# Altium schematic sheet GWMS.SchDoc (continued)

## Library data of the parts
C1 C3 C5 C7 C9 C12 C14 C16 C18 = Capacitor: Capacitor Non Polar; Manufacturer: Taiyo Yuden; Manufacturer Part Number: TMK316AB7106KLHT; Dielectric: X7R; Tolerance: 10%; Voltage Rating: 25V; Capacitance: 10uF; Case/Package: 1206; Case Code (Imperial): 1206; Case Code (Metric): 3216; Component Kind: Standard; Halogen Free: Halogen Free; Height: 1.6mm; Lead Free: Lead Free; Length: 0.126inch; Max Operating Temperature: 125°C; Min Operating Temperature: -55°C; Mount: Surface Mount; Package Quantity: 2000; Packaging: Tape and Reel; Pricing 1: 1 = 0.53000, 10 = 0.36800, 100 = 0.22110, 500 = 0.16580, 1000 = 0.14001 (USD); Ratings: AEC-Q200; RoHS: Yes; Simulation: CAP; Stock 1: 157997; Supplier 1: Digi-Key; Supplier Part Number 1: 587-3485-1-ND; Termination: SMD/SMT; Thickness: 0.071inch; Voltage Rating (DC): 25V; Width: 0.063inch; Library Name: RCL_roomi.IntLib
C2 C4 C6 C8 C10 C11 C13 C15 C17 C19 C20 C25 C28-C30 = Capacitor: Capacitor Non Polar; Manufacturer: Samsung; Manufacturer Part Number: CL21B104KCFWPNE; Tolerance: 10%; Voltage Rating: 100V; Capacitance: 100nF; Case/Package: 0805; Case Code (Imperial): 0805; Case Code (Metric): 2012; Component Kind: Standard; Features: Soft Termination; Length: 0.079inch; Max Operating Temperature: 125°C; Min Operating Temperature: -55°C; Mount: Surface Mount; Packaging: Tape and Reel; Pricing 1: 1 = 0.19000, 10 = 0.13400, 100 = 0.06310, 500 = 0.04422, 1000 = 0.03660 (USD); Ratings: AEC-Q200; RoHS: Yes; Simulation: CAP; Stock 1: 24573; Supplier 1: Digi-Key; Supplier Part Number 1: 1276-6733-1-ND; Thickness: 0.053inch; Width: 0.049inch; Library Name: RCL_roomi.IntLib
C21 = Capacitor: Capacitor Non Polar; Manufacturer: Samsung; Manufacturer Part Number: CL21B471KC6WPNC; Tolerance: 10%; Voltage Rating: 100V; Capacitance: 470pF; Case/Package: 0805; Case Code (Imperial): 0805; Case Code (Metric): 2012; Component Kind: Standard; Features: Soft Termination; Length: 0.079inch; Max Operating Temperature: 125°C; Min Operating Temperature: -55°C; Mount: Surface Mount; Packaging: Tape and Reel; Pricing 1: 1 = 0.12000, 10 = 0.08300, 100 = 0.03930, 500 = 0.02752, 1000 = 0.02279 (USD); Ratings: AEC-Q200; RoHS: Yes; Simulation: CAP; Stock 1: 22710; Supplier 1: Digi-Key; Supplier Part Number 1: 1276-6597-1-ND; Thickness: 0.028inch; Width: 0.049inch; Library Name: RCL_roomi.IntLib
C22 = Capacitor: Capacitor Non Polar; Manufacturer: Murata; Manufacturer Part Number: GCJ31CR71E475KA12L; Dielectric: X7R; Tolerance: 10%; Voltage Rating: 25V; Capacitance: 4.7uF; Case/Package: 1206; Case Code (Imperial): 1206; Case Code (Metric): 3216; Component Kind: Standard; ComponentLink1Description: http://www.murata.com/~/media/webrenewal/support/library/catalog/products/capacitor/mlcc/c03e.ashx; ComponentLink1URL: http://www.murata.com/~/media/webrenewal/support/library/catalog/products/capacitor/mlcc/c03e.ashx; ComponentLink2Description: http://search.murata.co.jp/Ceramy/image/img/A01X/partnumbering_e_02.pdf; ComponentLink2URL: http://search.murata.co.jp/Ceramy/image/img/A01X/partnumbering_e_02.pdf; Depth: 1.6mm; Features: Soft Termination; Lead Free: Lead Free; Length: 0.126inch; Max Operating Temperature: 125°C; Min Operating Temperature: -55°C; Mount: Surface Mount; Package Quantity: 2000; Packaging: Tape and Reel; Pricing 1: 1 = 0.82000, 10 = 0.57600, 100 = 0.37950, 500 = 0.28114, 1000 = 0.23897 (USD); Ratings: AEC-Q200; RoHS: Yes; Simulation: CAP; Stock 1: 80568; Supplier 1: Digi-Key; Supplier Part Number 1: 490-5808-1-ND; Termination: SMD/SMT; Thickness: 0.075inch; Voltage Rating (DC): 25V; Width: 0.063inch; Library Name: RCL_roomi.IntLib
C23 = Capacitor-Pol: Capacitor Polar; Manufacturer: Panasonic; Manufacturer Part Number: EEEHC1C471P; Dielectric Material: Aluminium; Leakage Current: 3uA; Life (Hours): 5000Hour; Ripple Current: 340mA; Tolerance: 20%; Capacitance: 470uF; Case/Package: Radial; Component Kind: Standard; ComponentLink1Description: http://www.farnell.com/datasheets/2059746.pdf; ComponentLink1URL: http://www.farnell.com/datasheets/2059746.pdf; ComponentLink2Description: http://industrial.panasonic.com/www-data/pdf/ABA0000/ABA0000CE109.pdf; ComponentLink2URL: http://industrial.panasonic.com/www-data/pdf/ABA0000/ABA0000CE109.pdf; ComponentLink3Description: http://www.farnell.com/datasheets/2244689.pdf; ComponentLink3URL: http://www.farnell.com/datasheets/2244689.pdf; ComponentLink4Description: http://www.farnell.com/datasheets/370234.pdf; ComponentLink4URL: http://www.farnell.com/datasheets/370234.pdf; ComponentLink5Description: https://www.alliedelec.com/m/d/b5cedb557dd62c1c43280c1d7d86d6d0.pdf; ComponentLink5URL: https://www.alliedelec.com/m/d/b5cedb557dd62c1c43280c1d7d86d6d0.pdf; ComponentLink6Description: http://www.mouser.com/ds/2/315/ABA0000C1160-947462.pdf; ComponentLink6URL: http://www.mouser.com/ds/2/315/ABA0000C1160-947462.pdf; ComponentLink7Description: http://uk.rs-online.com/webdocs/0f32/0900766b80f32c51.pdf; ComponentLink7URL: http://uk.rs-online.com/webdocs/0f32/0900766b80f32c51.pdf; Contact Plating: Tin; Diameter: 10mm; Height: 10.2mm; Height - Seated (Max): 0.402inch; Lead Free: Lead Free; Lead Pitch: 4.6mm; Length: 10.2mm; Max Operating Temperature: 105°C; Min Operating Temperature: -40°C; Mount: PCB,Surface Mount; Number of Pins: 2; Package Quantity: 500; Packaging: Tape and Reel; Polarity: Polar; Pricing 1: 1 = 0.85000, 10 = 0.62600, 100 = 0.42920 (USD); Radiation Hardening: No; REACH SVHC: No SVHC; Ripple Current (AC): 340mA; RoHS: Yes; Simulation: CAP; Stock 1: 14134; Supplier 1: Digi-Key; Supplier Part Number 1: PCE3751CT-ND; Termination: SMD/SMT; Voltage Rating: 16V; Voltage Rating (DC): 16V; Width: 900um; Library Name: RCL_roomi.IntLib
C24 C27 = Capacitor: Ceramic Chip Capacitor; Manufacturer: Samsung; Manufacturer Part Number: CL21B104KCFWPNE; Tolerance: 10%; Voltage Rating: 100V; Capacitance: 100nF; Case/Package: 0805; Case Code (Imperial): 0805; Case Code (Metric): 2012; Component Kind: Standard; Features: Soft Termination; Length: 0.079inch; Max Operating Temperature: 125°C; Min Operating Temperature: -55°C; Mount: Surface Mount; Packaging: Tape and Reel; Pricing 1: 1 = 0.19000, 10 = 0.13400, 100 = 0.06310, 500 = 0.04422, 1000 = 0.03660 (USD); Ratings: AEC-Q200; RoHS: Yes; Simulation: CAP; Stock 1: 24573; Supplier 1: Digi-Key; Supplier Part Number 1: 1276-6733-1-ND; Thickness: 0.053inch; Width: 0.049inch; Library Name: RCL_roomi.IntLib
C26 = Capacitor: Ceramic Chip Capacitor; Manufacturer: Taiyo Yuden; Manufacturer Part Number: TMK316AB7106KLHT; Dielectric: X7R; Tolerance: 10%; Voltage Rating: 25V; Capacitance: 10uF; Case/Package: 1206; Case Code (Imperial): 1206; Case Code (Metric): 3216; Component Kind: Standard; Halogen Free: Halogen Free; Height: 1.6mm; Lead Free: Lead Free; Length: 0.126inch; Max Operating Temperature: 125°C; Min Operating Temperature: -55°C; Mount: Surface Mount; Package Quantity: 2000; Packaging: Tape and Reel; Pricing 1: 1 = 0.53000, 10 = 0.36800, 100 = 0.22110, 500 = 0.16580, 1000 = 0.14001 (USD); Ratings: AEC-Q200; RoHS: Yes; Simulation: CAP; Stock 1: 157997; Supplier 1: Digi-Key; Supplier Part Number 1: 587-3485-1-ND; Termination: SMD/SMT; Thickness: 0.071inch; Voltage Rating (DC): 25V; Width: 0.063inch; Library Name: RCL_roomi.IntLib
D1 = Diode_Schottky: Manufacturer: ON Semiconductor / Fairchild; Average Rectified Current: 1A; Element Configuration: Single; Max Forward Surge Current (Ifsm): 40A; Max Repetitive Reverse Voltage (Vrrm): 40V; Reverse Recovery Time: 5.695ns; Case/Package: SOD; Component Kind: Standard; Max Reverse Voltage (DC): 40V; Mount: Surface Mount; Packaging: Tape and Reel; Pricing 1: 1 = 0.350000, 10 = 0.263000, 100 = 0.148900, 500 = 0.098620, 1000 = 0.075610 (USD); RoHS: Yes; Stock 1: 49252; Supplier 1: Digi-Key; Supplier Part Number 1: SS14FLCT-ND; Weight: 0.0276g; Library Name: Diodes_roomi.IntLib
D2 = Diode_Schottky: Manufacturer: Diodes; Average Rectified Current: 3A; Capacitance: 250pF; Element Configuration: Single; Forward Voltage: 500mV; Max Forward Surge Current (Ifsm): 80A; Max Repetitive Reverse Voltage (Vrrm): 40V; Peak Reverse Current: 500uA; Polarity: Standard; Case/Package: SMA; Component Kind: Standard; ComponentLink1Description: http://components.arrow.com/part/dataview/41507737S9851045N2867; ComponentLink1URL: http://components.arrow.com/part/dataview/41507737S9851045N2867; ComponentLink2Description: http://www.farnell.com/datasheets/1911813.pdf; ComponentLink2URL: http://www.farnell.com/datasheets/1911813.pdf; ComponentLink3Description: http://www.farnell.com/datasheets/2238474.pdf; ComponentLink3URL: http://www.farnell.com/datasheets/2238474.pdf; ComponentLink4Description: https://www.diodes.com/assets/Datasheets/ds30891.pdf; ComponentLink4URL: https://www.diodes.com/assets/Datasheets/ds30891.pdf; ComponentLink5Description: https://www.verical.com/server-webapp/html/getDatasheet?partID=143394; ComponentLink5URL: https://www.verical.com/server-webapp/html/getDatasheet?partID=143394; Contact Plating: Matte,Tin; Current: 3A; Current Rating: 3A; Forward Current: 3A; Height: 2.3mm; Lead Free: Lead Free; Length: 4.6mm; Max Operating Temperature: 125°C; Max Reverse Voltage (DC): 40V; Min Operating Temperature: -55°C; Mount: Surface Mount; Number of Pins: 2; Output Current: 3A; Package Quantity: 5000; Packaging: Tape and Reel; Peak Non-Repetitive Surge Current: 80A; Pricing 1: 1 = 0.46000, 10 = 0.37300, 100 = 0.25380, 500 = 0.19034, 1000 = 0.14276 (USD); Radiation Hardening: No; REACH SVHC: No SVHC; RoHS: Yes; Stock 1: 741506; Supplier 1: Digi-Key; Supplier Part Number 1: B340A-FDICT-ND; Termination: SMD/SMT; Voltage: 40V; Voltage Rating (DC): 40V; Weight: 0.002258oz; Width: 2.92mm; Library Name: Diodes_roomi.IntLib
FID1-FID3 = Fiducials: Alignment mark for the pick & place machine; Library Name: Misc_roomi.IntLib
J1 J2 J9 J10 = TERM_BLK-2P: Terminal Block 2 position; Manufacturer: Phoenix Contact; Manufacturer Part Number: 1729128; Approvals: CSA,UL; Connector Type: Screw; Current: 10A; Current Rating: 13.5A; Max Supply Voltage: 400V; Number of Circuits: 2; Number of Levels: 1; Pitch: 5.08mm; Test Voltage: 4kV; Voltage: 300V; Wire/Cable Gauge: 26AWG; Wire Gauge (Min): 14AWG; Color: Green; Component Kind: Standard; ComponentLink1Description: https://media.digikey.com/pdf/Data%20Sheets/Phoenix%20Contact%20PDFs/1729128.pdf; ComponentLink1URL: https://media.digikey.com/pdf/Data%20Sheets/Phoenix%20Contact%20PDFs/1729128.pdf; ComponentLink2Description: http://www.alliedelec.com/m/d/8fbcabaafcd0c56253c6cd72df81298d.pdf; ComponentLink2URL: http://www.alliedelec.com/m/d/8fbcabaafcd0c56253c6cd72df81298d.pdf; ComponentLink3Description: https://www.verical.com/server-webapp/html/getDatasheet?partID=199310; ComponentLink3URL: https://www.verical.com/server-webapp/html/getDatasheet?partID=199310; ComponentLink4Description: https://produktinfo.conrad.com/datenblaetter/725000-749999/743664-da-01-en-PRINTKLEMMENBLOCK_MKDSN_1_5__2_5_08.pdf; ComponentLink4URL: https://produktinfo.conrad.com/datenblaetter/725000-749999/743664-da-01-en-PRINTKLEMMENBLOCK_MKDSN_1_5__2_5_08.pdf; Contact Material: Tin; Depth: 8.1mm; Flammability Rating: UL94 V-0; Height: 13.5mm; Housing Color: Green; Housing Material: Polyamide; Insulation Material: Nylon; Lead Free: Lead Free; Length: 8.1mm; Max Current Rating: 13.5A; Max Operating Temperature: 100°C; Min Operating Temperature: -20°C; Mount: Through Hole; Number of Contacts: 2; Number of Pins: 2; Number of Poles: 2; Number of Positions: 2; Number of Positions Per Level: 2; Number of Rows: 1; Orientation: Straight; Package Quantity: 50; Packaging: Bulk; Pricing 1: 1 = 1.10000, 10 = 1.05100, 50 = 1.00480, 100 = 0.97740, 250 = 0.91352, 500 = 0.84042, 1000 = 0.77647, 2500 = 0.73994, 5000 = 0.69426 (USD); Radiation Hardening: No; REACH SVHC: No SVHC; RoHS: Yes; Stock 1: 62; Supplier 1: Digi-Key; Supplier Part Number 1: 277-1247-ND; Termination: Screw; Voltage Rating: 400V; Weight: 0.069842oz; Width: 13.5mm; Wire Gauge (Max): 26AWG; Library Name: Con_roomi.IntLib
J3 = HDR 1X3: HEADER 1X3; Component Kind: Standard; Library Name: Con_roomi.IntLib
J4 J18-J20 = Jumper-3P_NC: Component Kind: Net Tie; Library Name: Misc_roomi.IntLib
J5-J8 = HDR 1X3: HEADER 1X3; Manufacturer: Sullins; Manufacturer Part Number: PPPC031LFBN-RC; Connector Type: Header,Socket; Number of Positions: 3; Pitch: 0.1inch; Color: Black; Component Kind: Standard; Contact Material: Bronze; Contact Plating: Gold; Height: 8.5mm; Housing Material: Plastic; Lead Free: Lead Free; Length: 8.12mm; Mount: Through Hole; Number of Rows: 1; Package Quantity: 1000; Packaging: Bulk; Pricing 1: 1 = 0.37000, 10 = 0.35100, 100 = 0.26910, 500 = 0.23400, 1000 = 0.19305, 5000 = 0.16965, 10000 = 0.16900 (USD); RoHS: Yes; Stock 1: 16844; Supplier 1: Digi-Key; Supplier Part Number 1: S7036-ND; Termination: Solder; Width: 2.5mm; Library Name: Con_roomi.IntLib
J11 = HDR 1X2: HEADER 1X2; Component Kind: Standard; Library Name: Con_roomi.IntLib
J12 = TERM_BLK-5P: Terminal Block 4 position; Manufacturer: Phoenix Contact; Manufacturer Part Number: 1729157; Approvals: CB,CSA,cULus,UL; Connector Type: Screw; Current: 10A; Current Rating: 13.5A; Max Supply Voltage: 250V; Number of Circuits: 5; Number of Levels: 1; Pitch: 5.08mm; Stripping Length: 6mm; Voltage: 300V; Wire/Cable Gauge: 26AWG; Wire Gauge (Min): 14AWG; Color: Green; Component Kind: Standard; ComponentLink1Description: https://media.digikey.com/pdf/Data%20Sheets/Phoenix%20Contact%20PDFs/1729157.pdf?src-supplier=Digi-Key; ComponentLink1URL: https://media.digikey.com/pdf/Data%20Sheets/Phoenix%20Contact%20PDFs/1729157.pdf?src-supplier=Digi-Key; ComponentLink2Description: https://www.verical.com/server-webapp/html/getDatasheet?partID=238078&src-supplier=Verical; ComponentLink2URL: https://www.verical.com/server-webapp/html/getDatasheet?partID=238078&src-supplier=Verical; ComponentLink3Description: https://www.alliedelec.com/m/d/55f89f4a8d0b80308322c2ef4a018ccf.pdf?src-supplier=Allied+Electronics; ComponentLink3URL: https://www.alliedelec.com/m/d/55f89f4a8d0b80308322c2ef4a018ccf.pdf?src-supplier=Allied+Electronics; ComponentLink4Description: http://www.farnell.com/datasheets/2309723.pdf?src-supplier=Farnell; ComponentLink4URL: http://www.farnell.com/datasheets/2309723.pdf?src-supplier=Farnell; Contact Material: Tin; Depth: 8.1mm; Flammability Rating: UL94 V-0; Height: 13.5mm; Housing Color: Green; Housing Material: Polyamide; Insulation Material: Nylon; Lead Free: Lead Free; Length: 8.1mm; Material: Nylon; Max Current Rating: 13.5A; Mount: Through Hole; Number of Contacts: 5; Number of Pins: 5; Number of Poles: 5; Number of Positions: 5; Number of Positions Per Level: 5; Number of Rows: 1; Orientation: Straight; Package Quantity: 50; Packaging: Bulk; Pricing 1: 1 = 2.75000, 10 = 2.63200, 50 = 2.51800, 100 = 2.44920, 250 = 2.28900, 500 = 2.10590, 1000 = 1.94566, 2500 = 1.85409, 5000 = 1.73965 (USD); Radiation Hardening: No; REACH SVHC: No SVHC; RoHS: Yes; Stock 1: 3989; Supplier 1: Digi-Key; Supplier Part Number 1: 277-1250-ND; Termination: Solder; Voltage Rating: 250V; Weight: 0.160849oz; Width: 25.4mm; Wire Gauge (Max): 26AWG; Library Name: Con_roomi.IntLib
J13 J15 = HDR 1X4: HEADER 1X4; Component Kind: Standard; Library Name: Con_roomi.IntLib
J14 = JST-2P: JST 2 Pin, Shrouded Connector; Connector Type: Male Pin; Contact Resistance: 20mR; Current Rating: 2A; Gender: Male; Insulation Resistance: 1MR; Max Voltage Rating (AC): 100V; Number of Contacts: 2; Pitch: 2mm; Color: Natural; Component Kind: Standard; ComponentLink1Description: http://www.farnell.com/datasheets/2057211.pdf; ComponentLink1URL: http://www.farnell.com/datasheets/2057211.pdf; ComponentLink2Description: http://www.jst-mfg.com/product/pdf/eng/ePH.pdf; ComponentLink2URL: http://www.jst-mfg.com/product/pdf/eng/ePH.pdf; ComponentLink3Description: http://www1.futureelectronics.com/doc/JST/B2B-PH-K-S(LF)(SN).pdf; ComponentLink3URL: http://www1.futureelectronics.com/doc/JST/B2B-PH-K-S(LF)(SN).pdf; Contact Material: Brass,Tin; Contact Mating Length: 0.13inch; Contact Plating: Copper,Tin; Depth: 4.5mm; Fastening Type: Detent; Height: 9.4mm; Housing Color: White; Housing Material: Polyamide; Lead Free: Lead Free; Lead Pitch: 2mm; Length: 5.9mm; Manufacturer: JST; Max Current Rating: 2A; Max Operating Temperature: 85°C; Max Voltage Rating (DC): 100V; Min Operating Temperature: -25°C; Mount: Through Hole; Number of Pins: 2; Number of Poles: 2; Number of Positions: 2; Number of Rows: 1; Orientation: Straight; Packaging: Bulk; Pricing 1: 1 = 0.170000, 10 = 0.162000, 100 = 0.100200, 500 = 0.082880, 1000 = 0.072520, 5000 = 0.062160, 10000 = 0.060440 (USD); REACH SVHC: No SVHC; RoHS: Yes; Stock 1: 457571; Supplier 1: Digi-Key; Supplier Part Number 1: 455-1704-ND; Termination: Solder; Voltage Rating: 100V; Voltage Rating (AC): 100V; Width: 4.5mm; Working Voltage: 100V; Library Name: Con_roomi.IntLib
J16 = Barrel Jack: DC Barrel Jack Connector Male; Manufacturer: Wurth Electronics; Connector Type: Jack,Power; Current Rating: 2A; Insulation Resistance: 100MR; Shielding: Unshielded; Voltage Rating: 30V; Color: Black; Component Kind: Standard; ComponentLink1Description: Manufacturer Link; ComponentLink1URL: http://domino.kycon.com/internet/Products.html; Contact Gender: Female; Contact Material: Copper Alloy; Contact Plating: Tin; Flammability Rating: UL94 V-0; Gender: Male; Housing Material: Polyamide; Max Operating Temperature: 85°C; Min Operating Temperature: -40°C; Mount: Through Hole; Package Quantity: 1000; Packaging: Bulk; Pricing 1: 1 = 0.84000, 10 = 0.80100, 50 = 0.71500, 100 = 0.65800, 500 = 0.57200, 1000 = 0.48700 (USD); REACH SVHC: Unknown; RoHS: Yes; Stock 1: 1123; Supplier 1: Digi-Key; Supplier Part Number 1: 732-5925-ND; Termination: Through Hole; Voltage Rating (DC): 30V; Library Name: Con_roomi.IntLib
J17 = MicroSD: MicroSD Connector; Manufacturer: Hirose; Manufacturer Part Number: DM3CS-SF; Connector Type: Connector,Socket; Contact Resistance: 100mR; Current Rating: 500mA; Gender: Female; Insulation Resistance: 1GR; Number of Contacts: 8; Pitch: 1.1mm; Voltage Rating (AC): 125V; Component Kind: Standard; ComponentLink1Description: https://www.hirose.com/product/en/download_file/key_name/DM3CS-SF/category/Specification%20Sheet/doc_file_id/44102/?file_category_id=9&item_id=06090032300&is_series=; ComponentLink1URL: https://www.hirose.com/product/en/download_file/key_name/DM3CS-SF/category/Specification%20Sheet/doc_file_id/44102/?file_category_id=9&item_id=06090032300&is_series=; ComponentLink2Description: http://www.farnell.com/datasheets/1697167.pdf; ComponentLink2URL: http://www.farnell.com/datasheets/1697167.pdf; ComponentLink3Description: http://www.hirose.co.jp/cataloge_hp/e60900232.pdf; ComponentLink3URL: http://www.hirose.co.jp/cataloge_hp/e60900232.pdf; ComponentLink4Description: http://www.mouser.com/ds/2/185/DM3_catalog-939223.pdf; ComponentLink4URL: http://www.mouser.com/ds/2/185/DM3_catalog-939223.pdf; Contact Material: Copper,Copper Alloy,Gold; Contact Plating: Gold; Depth: 1.83mm; Flammability Rating: UL94 V-0; Housing Material: Polymer; Lead Free: Lead Free; Length: 14.1mm; Max Current Rating: 500mA; Max Operating Temperature: 85°C; Min Operating Temperature: -25°C; Mount: Surface Mount; Number of Positions: 8; Number of Rows: 1; Orientation: Right Angle; Package Quantity: 1; Packaging: Tape and Reel; Pricing 1: 1 = 1.51000, 10 = 1.37400, 25 = 1.29040, 50 = 1.23420, 100 = 1.17810, 250 = 1.06592, 500 = 0.98176 (USD); Radiation Hardening: No; REACH SVHC: Unknown; RoHS: Yes; Stock 1: 67611; Supplier 1: Digi-Key; Supplier Part Number 1: HR1972CT-ND; Termination: Solder; Width: 13.8mm; Library Name: Con_roomi.IntLib
L1 = Inductor: Generic Inductor; Manufacturer: Taiyo Yuden; Manufacturer Part Number: NR5040T100M; Core Material: Ferrite; Current Rating: 2.1A; DC Resistance (DCR): 72.8mR; Inductance Tolerance: 20%; Max DC Current: 2.3A; Self Resonant Frequency: 18MHz; Shielding: Shielded; Test Frequency: 100kHz; Case/Package: Nonstandard; Component Kind: Standard; ComponentLink1Description: https://www.yuden.co.jp/productdata/catalog/en/wound04_e.pdf; ComponentLink1URL: https://www.yuden.co.jp/productdata/catalog/en/wound04_e.pdf; ComponentLink2Description: https://www.yuden.co.jp/productdata/catalog/wound04_e.pdf; ComponentLink2URL: https://www.yuden.co.jp/productdata/catalog/wound04_e.pdf; ComponentLink3Description: http://www.yuden.co.jp/productdata/catalog/en/wound04_e.pdf; ComponentLink3URL: http://www.yuden.co.jp/productdata/catalog/en/wound04_e.pdf; ComponentLink4Description: http://www.mouser.com/ds/2/396/wound04_e-8385.pdf; ComponentLink4URL: http://www.mouser.com/ds/2/396/wound04_e-8385.pdf; Composition: Wirewound; Depth: 5.1mm; Halogen Free: Halogen Free; Height: 4mm; Height - Seated (Max): 0.161inch; Inductance: 10uH; Length: 0.193inch; Max Operating Temperature: 120°C; Min Operating Temperature: -25°C; Mount: Surface Mount; Number of Pins: 2; Package Quantity: 1500; Packaging: Tape and Reel; Pricing 1: 1 = 0.45000, 10 = 0.39000, 100 = 0.27890, 500 = 0.23426 (USD); Radiation Hardening: No; REACH SVHC: Unknown; RMS Current (Irms): 2.1A; RoHS: Yes; Saturation Current: 2.3A; Stock 1: 25005; Supplier 1: Digi-Key; Supplier Part Number 1: 587-2365-1-ND; Termination: SMD/SMT; Tolerance: 20%; Width: 0.193inch; Library Name: RCL_roomi.IntLib
M1 = Particle: Particle BORON, ARGON and XENON Module; Component Kind: Standard; ComponentLink1Description: http://www.microchip.com/mymicrochip/filehandler.aspx?ddocname=en570043; ComponentLink1URL: http://www.microchip.com/mymicrochip/filehandler.aspx?ddocname=en570043; Manufacturer: Particle; Mounting Type: Through Hole; Operating Temperature: -20°C ~ 60°C; Package / Case: Module; Library Name: Modules_Roomi.IntLib
Q1 Q2 = MOSFET-N_D: N-Channel Power MOSFET with Diode; Manufacturer: ON Semiconductor; Continuous Drain Current (ID): 320mA; Drain to Source Breakdown Voltage: 60V; Drain to Source Resistance: 1.6R; Element Configuration: Single; Fall Time: 9ns; Gate to Source Voltage (Vgs): 20V; Input Capacitance: 24.5pF; Max Power Dissipation: 420mW; Nominal Vgs: 2.3V; Number of Elements: 1; Power Dissipation: 300mW; Turn-Off Delay Time: 55.8ns; Turn-On Delay Time: 12.2ns; Case/Package: SOT-23-3; Component Kind: Standard; ComponentLink1Description: Manufacturer Link; ComponentLink1URL: http://www.irf.com/; ComponentLink2Description: http://www.mouser.com/ds/2/308/2N7002K-D-40616.pdf; ComponentLink2URL: http://www.mouser.com/ds/2/308/2N7002K-D-40616.pdf; ComponentLink3Description: http://www.farnell.com/datasheets/2354033.pdf; ComponentLink3URL: http://www.farnell.com/datasheets/2354033.pdf; ComponentLink4Description: http://www.onsemi.ru.com/pub/Collateral/2N7002K-D.PDF; ComponentLink4URL: http://www.onsemi.ru.com/pub/Collateral/2N7002K-D.PDF; ComponentLink5Description: https://www.verical.com/server-webapp/html/getDatasheet?partID=309924; ComponentLink5URL: https://www.verical.com/server-webapp/html/getDatasheet?partID=309924; ComponentLink6Description: http://www.onsemi.com/pub_link/Collateral/2N7002K-D.PDF; ComponentLink6URL: http://www.onsemi.com/pub_link/Collateral/2N7002K-D.PDF; ComponentLink7Description: http://uk.rs-online.com/webdocs/13e9/0900766b813e9f06.pdf; ComponentLink7URL: http://uk.rs-online.com/webdocs/13e9/0900766b813e9f06.pdf; ComponentLink8Description: http://www.onsemi.com/pub/Collateral/2N7002K-D.PDF; ComponentLink8URL: http://www.onsemi.com/pub/Collateral/2N7002K-D.PDF; Contact Plating: Matte,Tin; Halogen Free: Halogen Free; Height: 1.01mm; Lead Free: Lead Free; Length: 3.04mm; Max Operating Temperature: 150°C; Min Operating Temperature: -55°C; Number of Pins: 3; On-State Resistance: 1.6R; Package Quantity: 1; Packaging: Tape and Reel; Pricing 1: 1 = 0.21000, 10 = 0.19300, 100 = 0.12640, 500 = 0.06180, 1000 = 0.04214 (USD); Radiation Hardening: No; Rds On Max: 1.6R; REACH SVHC: No SVHC; Rise Time: 9ns; RoHS: Yes; Simulation: IRF1010; Stock 1: 21780; Supplier 1: Digi-Key; Supplier Part Number 1: 2N7002KT1GOSCT-ND; Threshold Voltage: 2.3V; Width: 1.4mm; Library Name: Transistors_roomi.IntLib
R1 R2 R7 R8 R18 = Resistor: Generic Resistor; Manufacturer: Yageo; Manufacturer Part Number: AC0805FR-071ML; Max Power Dissipation: 125mW; Temperature Coefficient: 100ppm/°C; Tolerance: 1%; Voltage Rating: 150V; Case/Package: 0805; Case Code (Imperial): 0805; Case Code (Metric): 2012; Component Kind: Standard; Composition: Thick Film; Features: Automotive AEC-Q200,Moisture Resistant; Height: 0.024inch; Lead Free: Lead Free; Length: 0.079inch; Max Operating Temperature: 155°C; Min Operating Temperature: -55°C; Number of Terminations: 2; Packaging: Tape and Reel; Power Rating: 125mW; Pricing 1: 1 = 0.10000, 10 = 0.05000, 100 = 0.02050, 1000 = 0.00921, 2500 = 0.00799 (USD); Resistance: 1MR; RoHS: Yes; Simulation: RESISTOR; Stock 1: 16924; Supplier 1: Digi-Key; Supplier Part Number 1: YAG3704CT-ND; Termination: SMD/SMT; Width: 0.049inch; Library Name: RCL_roomi.IntLib
R3-R6 R20-R23 R28 R29 R31 R34 = Resistor: Generic Resistor; Manufacturer: Yageo; Manufacturer Part Number: AC0805FR-074K7L; Max Power Dissipation: 125mW; Temperature Coefficient: 100ppm/°C; Tolerance: 1%; Voltage Rating: 150V; Case/Package: 0805; Case Code (Imperial): 0805; Case Code (Metric): 2012; Component Kind: Standard; ComponentLink1Description: http://www.mouser.com/ds/2/447/yageodocoutput-1111992.pdf; ComponentLink1URL: http://www.mouser.com/ds/2/447/yageodocoutput-1111992.pdf; Composition: Thick Film; Depth: 1.25mm; Features: Automotive AEC-Q200,Moisture Resistant; Height: 0.024inch; Lead Free: Lead Free; Length: 2mm; Max Operating Temperature: 155°C; Military Standard: Not; Min Operating Temperature: -55°C; Number of Terminations: 2; Packaging: Tape and Reel; Power Rating: 125mW; Pricing 1: 1 = 0.10000, 10 = 0.05000, 100 = 0.02050, 1000 = 0.00921, 2500 = 0.00799 (USD); Resistance: 4.7kR; RoHS: Yes; Simulation: RESISTOR; Stock 1: 7830; Supplier 1: Digi-Key; Supplier Part Number 1: YAG3738CT-ND; Termination: SMD/SMT; Width: 0.049inch; Library Name: RCL_roomi.IntLib
R9 R10 = Resistor: Generic Resistor; Manufacturer: Yageo; Manufacturer Part Number: RC0805FR-074R99L; Max Power Dissipation: 125mW; Temperature Coefficient: 200ppm/°C; Tolerance: 1%; Voltage Rating: 150V; Case/Package: 0805; Case Code (Imperial): 0805; Case Code (Metric): 2012; Component Kind: Standard; ComponentLink1Description: http://www.yageo.com/documents/recent/PYu-RC_Group_51_RoHS_L_9.pdf; ComponentLink1URL: http://www.yageo.com/documents/recent/PYu-RC_Group_51_RoHS_L_9.pdf; ComponentLink2Description: http://www.yageo.com/documents/recent/PYu-RC_Group_51_RoHS_L_10.pdf; ComponentLink2URL: http://www.yageo.com/documents/recent/PYu-RC_Group_51_RoHS_L_10.pdf; ComponentLink3Description: http://www.yageo.ru/pdf/RC0805.pdf; ComponentLink3URL: http://www.yageo.ru/pdf/RC0805.pdf; ComponentLink4Description: http://www.mouser.com/ds/2/447/Yageo_08112016_RC0805-968729.pdf; ComponentLink4URL: http://www.mouser.com/ds/2/447/Yageo_08112016_RC0805-968729.pdf; Composition: Thick Film; Depth: 1.25mm; Features: Moisture Resistant; Height: 0.024inch; Lead Free: Lead Free; Length: 2mm; Max Operating Temperature: 155°C; Military Standard: Not; Min Operating Temperature: -55°C; Number of Terminations: 2; Package Quantity: 1; Packaging: Tape and Reel; Power Rating: 125mW; Pricing 1: 1 = 0.10000, 10 = 0.07500, 100 = 0.02880, 1000 = 0.01268, 2500 = 0.01095 (USD); Resistance: 4.99R; RoHS: Yes; Simulation: RESISTOR; Stock 1: 471256; Supplier 1: Digi-Key; Supplier Part Number 1: 311-4.99CRCT-ND; Termination: SMD/SMT; Voltage Rating (DC): 150V; Weight: 0.000194oz; Width: 0.049inch; Library Name: RCL_roomi.IntLib
R11 R19 R38 = Resistor: Generic Resistor; Manufacturer: Vishay; Manufacturer Part Number: CRCW080584K5FKEA; Max Power Dissipation: 125mW; Temperature Coefficient: 100ppm/°C; Tolerance: 1%; Voltage Rating: 150V; Case/Package: 0805; Case Code (Imperial): 0805; Case Code (Metric): 2012; Component Kind: Standard; ComponentLink1Description: http://www.vishay.com/docs/20035/dcrcwe3.pdf; ComponentLink1URL: http://www.vishay.com/docs/20035/dcrcwe3.pdf; ComponentLink2Description: http://www.farnell.com/datasheets/2049244.pdf; ComponentLink2URL: http://www.farnell.com/datasheets/2049244.pdf; ComponentLink3Description: http://www.farnell.com/datasheets/2111203.pdf; ComponentLink3URL: http://www.farnell.com/datasheets/2111203.pdf; ComponentLink4Description: http://www.mouser.com/ds/2/427/dcrcwe3-109170.pdf; ComponentLink4URL: http://www.mouser.com/ds/2/427/dcrcwe3-109170.pdf; Composition: Thick Film; Contact Plating: Tin; Depth: 1.25mm; Features: Automotive AEC-Q200; Height: 0.02inch; Lead Free: Lead Free; Length: 2mm; Max Operating Temperature: 155°C; Military Standard: Not; Min Operating Temperature: -55°C; Mount: Surface Mount; Number of Pins: 2; Number of Terminations: 2; Package Quantity: 10; Packaging: Tape and Reel; Power Rating: 125mW; Pricing 1: 1 = 0.10000, 10 = 0.06900, 100 = 0.02800, 1000 = 0.01256, 2500 = 0.01090 (USD); Radiation Hardening: No; REACH SVHC: Unknown; Resistance: 84.5kR; RoHS: Yes; Simulation: RESISTOR; Stock 1: 16539; Supplier 1: Digi-Key; Supplier Part Number 1: 541-84.5KCCT-ND; Voltage Rating (DC): 150V; Weight: 0.000194oz; Width: 0.049inch; Library Name: RCL_roomi.IntLib
R12-R17 = Resistor: Generic Resistor; Manufacturer: Yageo; Manufacturer Part Number: AC0805FR-071K5L; Max Power Dissipation: 125mW; Temperature Coefficient: 100ppm/°C; Tolerance: 1%; Voltage Rating: 150V; Case/Package: 0805; Case Code (Imperial): 0805; Case Code (Metric): 2012; Component Kind: Standard; Composition: Thick Film; Features: Automotive AEC-Q200,Moisture Resistant; Height: 0.024inch; Length: 0.079inch; Max Operating Temperature: 155°C; Min Operating Temperature: -55°C; Number of Terminations: 2; Packaging: Tape and Reel; Power Rating: 125mW; Pricing 1: 1 = 0.10000, 10 = 0.05000, 100 = 0.02050, 1000 = 0.00921, 2500 = 0.00799 (USD); Resistance: 1.5kR; RoHS: Yes; Simulation: RESISTOR; Stock 1: 5572; Supplier 1: Digi-Key; Supplier Part Number 1: YAG3701CT-ND; Termination: SMD/SMT; Width: 0.049inch; Library Name: RCL_roomi.IntLib
R24 = Resistor: Generic Resistor; Component Kind: Standard; LatestRevisionDate: 17-Jul-2002; LatestRevisionNote: Re-released for DXP Platform.; PackageReference: SMD; Published: 8-Jun-2000; Simulation: RESISTOR; Library Name: RCL_roomi.IntLib; Publisher: Altium Limited
R25-R27 R35-R37 = Resistor: Generic Resistor; Manufacturer: Yageo; Manufacturer Part Number: AC0805FR-0710KL; Max Power Dissipation: 125mW; Temperature Coefficient: 100ppm/°C; Tolerance: 1%; Voltage Rating: 150V; Case/Package: 0805; Case Code (Imperial): 0805; Case Code (Metric): 2012; Component Kind: Standard; ComponentLink1Description: http://www.yageo.com/NewPortal/yageodocoutput?fileName=/pdf/R-Chip/PYu-AC_51_RoHS_L_6.pdf; ComponentLink1URL: http://www.yageo.com/NewPortal/yageodocoutput?fileName=/pdf/R-Chip/PYu-AC_51_RoHS_L_6.pdf; Composition: Thick Film; Depth: 1.25mm; Features: Anti-Sulfur,Automotive AEC-Q200,Moisture Resistant; Height: 0.024inch; Lead Free: Lead Free; Length: 0.079inch; Max Operating Temperature: 155°C; Military Standard: Not; Min Operating Temperature: -55°C; Number of Terminations: 2; Package Quantity: 1; Packaging: Tape and Reel; Power Rating: 125mW; Pricing 1: 1 = 0.10000, 10 = 0.05000, 100 = 0.02050, 1000 = 0.00921, 2500 = 0.00799 (USD); Resistance: 10kR; RoHS: Yes; Simulation: RESISTOR; Stock 1: 812288; Supplier 1: Digi-Key; Supplier Part Number 1: 311-10KLGCT-ND; Termination: SMD/SMT; Width: 0.049inch; Library Name: RCL_roomi.IntLib
R30 = Resistor: Generic Resistor; Manufacturer: Bourns; Manufacturer Part Number: CRA2512-FZ-R080ELF; Max Power Dissipation: 3W; Temperature Coefficient: 75ppm/°C; Tolerance: 1%; Case/Package: 2512; Case Code (Imperial): 2512; Case Code (Metric): 6432; Component Kind: Standard; ComponentLink1Description: http://www.bourns.com/data/global/pdfs/CRA.pdf; ComponentLink1URL: http://www.bourns.com/data/global/pdfs/CRA.pdf; ComponentLink2Description: http://www.bourns.com/docs/Product-Datasheets/CRA.pdf; ComponentLink2URL: http://www.bourns.com/docs/Product-Datasheets/CRA.pdf; ComponentLink3Description: https://www.bourns.com/docs/Product-Datasheets/CRA.pdf; ComponentLink3URL: https://www.bourns.com/docs/Product-Datasheets/CRA.pdf; ComponentLink4Description: http://www.farnell.com/datasheets/2253366.pdf; ComponentLink4URL: http://www.farnell.com/datasheets/2253366.pdf; ComponentLink5Description: http://uk.rs-online.com/webdocs/0ddc/0900766b80ddce06.pdf; ComponentLink5URL: http://uk.rs-online.com/webdocs/0ddc/0900766b80ddce06.pdf; Composition: Metal Film,Thick Film; Contact Plating: Tin; Depth: 3.2mm; Features: Moisture Resistant; Height: 0.6mm; Length: 6.4mm; Max Operating Temperature: 170°C; Military Standard: Not; Min Operating Temperature: -55°C; Mount: Surface Mount; Number of Pins: 2; Number of Terminations: 2; Package Quantity: 1; Packaging: Tape and Reel; Power Rating: 3W; Pricing 1: 1 = 0.69000, 10 = 0.57800, 25 = 0.49560, 50 = 0.44600, 100 = 0.38810, 250 = 0.34684, 500 = 0.28078, 1000 = 0.22710 (USD); Radiation Hardening: No; REACH SVHC: No SVHC; Resistance: 80mR; RoHS: Yes; Simulation: RESISTOR; Stock 1: 115511; Supplier 1: Digi-Key; Supplier Part Number 1: CRA2512-FZ-R080ELFCT-ND; Termination: SMD/SMT; Weight: 0.001429oz; Width: 3.2mm; Library Name: RCL_roomi.IntLib
R32 R33 = Resistor: Generic Resistor; Manufacturer: Yageo; Manufacturer Part Number: AC0805FR-0710RL; Max Power Dissipation: 125mW; Temperature Coefficient: 200ppm/°C; Tolerance: 1%; Voltage Rating: 150V; Case/Package: 0805; Case Code (Imperial): 0805; Case Code (Metric): 2012; Component Kind: Standard; Composition: Thick Film; Depth: 1.25mm; Features: Automotive AEC-Q200,Moisture Resistant; Height: 0.024inch; Lead Free: Lead Free; Length: 2mm; Max Operating Temperature: 155°C; Military Standard: Not; Min Operating Temperature: -55°C; Number of Terminations: 2; Packaging: Tape and Reel; Power Rating: 125mW; Pricing 1: 1 = 0.10000, 10 = 0.09000, 100 = 0.03460, 1000 = 0.01522, 2500 = 0.01315 (USD); Resistance: 10R; RoHS: Yes; Simulation: RESISTOR; Stock 1: 569278; Supplier 1: Digi-Key; Supplier Part Number 1: YAG3690CT-ND; Termination: SMD/SMT; Width: 1.25mm; Library Name: RCL_roomi.IntLib
S1 = Slide_DPDT: Slide Switch DPDT; Manufacturer: ITT C&K; Manufacturer Part Number: JS202011CQN; Actuator Length: 2mm; Actuator Material: Nylon,Polyamide; Actuator Type: Standard; Contact Current Rating: 100mA; Contact Resistance: 70mR; Current Rating: 300mA; Electrical Life: 5000Cycles; Illumination Type: Non-Illuminated; Max Voltage Rating (DC): 6V; Switch Function: On-On; Component Kind: Standard; ComponentLink1Description: http://www.farnell.com/datasheets/1707718.pdf?src-supplier=Element14; ComponentLink1URL: http://www.farnell.com/datasheets/1707718.pdf?src-supplier=Element14; ComponentLink2Description: http://www.ck-components.com/14398/js_1nov12.pdf/?src-supplier=Avnet; ComponentLink2URL: http://www.ck-components.com/14398/js_1nov12.pdf/?src-supplier=Avnet; ComponentLink3Description: https://www.ckswitches.com/media/1422/js.pdf?src-supplier=Digi-Key; ComponentLink3URL: https://www.ckswitches.com/media/1422/js.pdf?src-supplier=Digi-Key; Contact Material: Copper Alloy; Contact Plating: Silver; Depth: 3.6mm; Flammability Rating: UL94 V-0; Housing Material: Nylon; Lead Free: Lead Free; Length: 9mm; Max Current Rating: 300mA; Max Operating Temperature: 85°C; Min Operating Temperature: -40°C; Mount: Through Hole; Orientation: Vertical; Package Quantity: 1; Packaging: Bulk; Pricing 1: 1 = 0.43000, 10 = 0.41600, 25 = 0.40720, 50 = 0.36380, 100 = 0.34650, 250 = 0.33784, 500 = 0.28586, 1000 = 0.27720, 5000 = 0.24255 (USD); Radiation Hardening: No; REACH SVHC: No SVHC; RoHS: Yes; Stock 1: 46355; Supplier 1: Digi-Key; Supplier Part Number 1: 401-2001-ND; Switch Travel: 2mm; Termination: PC Pins; Throw Configuration: DPDT; Voltage Rating (DC): 6V; Library Name: Switches_roomi.IntLib
U1 U2 = ADM3260ARSZ: ANALOG DEVICES         ADM3260ARSZ            Digital Isolator, 2 Channel, 3 V, 5.5 V, SSOP, 20 Pins; Channel Type: Bidirectional; Data Rate: 1Mbps; Isolated Power: Yes; Max Input Current: 30mA; Max Power Dissipation: 2.5W; Max Supply Voltage: 5.5V; Min Supply Voltage: 3V; Number of Channels: 2; Operating Supply Current: 9.5mA; Propagation Delay: 95ns; Pulse Width Distortion (PWD): 85ns; Rise Time: 1.2us; Case/Package: SSOP; Component Kind: Standard; Contact Plating: Matte,Tin; Depth: 1.95mm; Lead Free: Contains Lead; Length: 7.5mm; Manufacturer: Analog Devices; Manufacturer Part Number: ADM3260ARSZ; Max Operating Temperature: 105°C; Max Output Current: 30mA; Min Operating Temperature: -40°C; Mount: Surface Mount; Number of Pins: 20; Package Quantity: 66; Packaging: Rail/Tube; Polarity: Bidirectional; Pricing 1: 1 = 8.26000, 66 = 7.11348, 132 = 6.17659, 528 = 5.37850, 1056 = 4.68450 (USD); REACH SVHC: No SVHC; RoHS: Yes; Stock 1: 7547; Supplier 1: Digi-Key; Supplier Part Number 1: ADM3260ARSZ-ND; Weight: 0.016oz; Width: 5.6mm; Library Name: IC_roomi.IntLib
U3 U4 = INA196AIDBVR: IC CURRENT MONITOR 3% SOT23-5; Manufacturer: Texas Instruments; Bandwidth: 500kHz; Common Mode Rejection Ratio: 80dB; Input Bias Current: 16uA; Input Offset Voltage (Vos): 2mV; Max Input Voltage: 80V; Max Supply Voltage: 18V; Min Input Voltage: -16V; Min Supply Voltage: 2.7V; Number of Amplifiers: 2; Number of Channels: 1; Operating Supply Current: 900uA; Output Type: Voltage; Power Supply Rejection Ratio (PSRR): 106dB; Quiescent Current: 700uA; Voltage Gain: 26.02dB; Accuracy: 3%; Case/Package: SOT-23; Component Kind: Standard; ComponentLink1Description: http://www.ti.com/general/docs/lit/getliterature.tsp?genericPartNumber=INA193&&fileType=pdf; ComponentLink1URL: http://www.ti.com/general/docs/lit/getliterature.tsp?genericPartNumber=INA193&&fileType=pdf; ComponentLink2Description: http://www.ti.com/lit/ds/symlink/ina193.pdf; ComponentLink2URL: http://www.ti.com/lit/ds/symlink/ina193.pdf; ComponentLink3Description: https://www.verical.com/server-webapp/html/getDatasheet?partID=241489; ComponentLink3URL: https://www.verical.com/server-webapp/html/getDatasheet?partID=241489; ComponentLink4Description: http://www.produktinfo.conrad.com/datenblaetter/1000000-1099999/001013575-da-01-en-IC_CURRENT_MONITO_INA196AIDBVR_SOT_5_TID.pdf; ComponentLink4URL: http://www.produktinfo.conrad.com/datenblaetter/1000000-1099999/001013575-da-01-en-IC_CURRENT_MONITO_INA196AIDBVR_SOT_5_TID.pdf; Contact Plating: Matte,Tin; Lead Free: Lead Free; Manufacturer Part Number: INA196AIDBVR; Max Operating Temperature: 125°C; Min Operating Temperature: -40°C; Number of Elements: 2; Number of Pins: 5; Package Quantity: 1; Packaging: Tape and Reel; Pricing 1: 1 = 2.27000, 10 = 2.04200, 100 = 1.64100, 500 = 1.34828, 1000 = 1.11714 (USD); Radiation Hardening: No; REACH SVHC: No SVHC; RoHS: Yes; Stock 1: 52256; Supplier 1: Digi-Key; Supplier Part Number 1: 296-26068-1-ND; Weight: 0.000617oz; Library Name: IC_roomi.IntLib
U5 = MIC2250-2YD5TR: Conv DC-DC Single Step Up 2.5V to 5.5V 5-Pin TSOT-23 T/R; Manufacturer: Microchip Micrel; Efficiency: 90%; Max Output Current: 2A; Max Output Voltage: 32V; Min Output Voltage: 1.24V; Number of Outputs: 1; Output Configuration: Positive; Output Current: 900mA; Output Type: Adjustable; Switching Frequency: 2.5MHz; Synchronous Rectifier: No; Topology: Boost; Case/Package: SOT-23-5; Component Kind: Standard; ComponentLink1Description: http://www.micrel.com/_PDF/mic2250.pdf; ComponentLink1URL: http://www.micrel.com/_PDF/mic2250.pdf; Height: 0.87mm; Length: 2.9mm; Manufacturer Part Number: MIC2250-2YD5TR; Max Input Voltage: 5.5V; Max Operating Temperature: 125°C; Min Input Voltage: 2.5V; Min Operating Temperature: -40°C; Mount: Surface Mount; Number of Pins: 5; Output Voltage: 32V; Package Quantity: 1; Packaging: Tape and Reel; Pricing 1: 1 = 0.73000, 25 = 0.61800, 100 = 0.55620 (USD); RoHS: Yes; Stock 1: 5054; Supplier 1: Digikey; Supplier Part Number 1: 576-3968-1-ND; Width: 1.6mm; Library Name: IC_roomi.IntLib
U6 = ADS1115IDGSR: IC ADC 16BIT 860SPS LP 10MSOP; Manufacturer: Texas Instruments; Conversion Rate: 0.86KS/s; Integral Nonlinearity (INL): 1LSB; Interface: I2C; Max Supply Voltage: 5.5V; Min Supply Voltage: 2V; Number of A/D Converters: 1; Number of Bits: 16; Number of Inputs: 4; Polarity: Bipolar; Power Dissipation: 900uW; Resolution: 16b; Sampling Rate: 860S/s; Supply Type: Single; Case/Package: VSSOP; Component Kind: Standard; ComponentLink1Description: http://components.arrow.com/part/dataview/47725463S8438921N7713; ComponentLink1URL: http://components.arrow.com/part/dataview/47725463S8438921N7713; ComponentLink2Description: http://www.ti.com/lit/ds/sbas444b/sbas444b.pdf; ComponentLink2URL: http://www.ti.com/lit/ds/sbas444b/sbas444b.pdf; ComponentLink3Description: http://www.ti.com/lit/ds/symlink/ads1113.pdf; ComponentLink3URL: http://www.ti.com/lit/ds/symlink/ads1113.pdf; Contact Plating: Gold; Lead Free: Lead Free; Manufacturer Part Number: ADS1115IDGSR; Max Operating Temperature: 125°C; Max Supply Voltage (DC): 5.5V; Min Operating Temperature: -40°C; Min Supply Voltage (DC): 2V; Number of Converters: 1; Number of Pins: 10; Package Quantity: 2500; Packaging: Tape and Reel; Pricing 1: 1 = 5.52000, 10 = 4.96200, 100 = 4.06520, 500 = 3.46064, 1000 = 2.91861 (USD); Radiation Hardening: No; REACH SVHC: No SVHC; RoHS: Yes; Stock 1: 20056; Supplier 1: Digi-Key; Supplier Part Number 1: 296-38849-1-ND; Library Name: IC_roomi.IntLib
U7 = SN74AHC126D: IC BUS BUFFER TRI-ST QD 14SOIC; Manufacturer: Texas Instruments; High Level Output Current: -8mA; Logic Function: Buffer,Inverting; Low Level Output Current: 8mA; Max Supply Voltage: 5.5V; Min Supply Voltage: 2V; Number of Bits: 4; Number of Bits per Element: 1; Polarity: Non-Inverting; Propagation Delay: 7.5ns; Quiescent Current: 4uA; Turn-On Delay Time: 15ns; Case/Package: SOIC; Component Kind: Standard; ComponentLink1Description: http://www.ti.com/general/docs/lit/getliterature.tsp?genericPartNumber=SN54AHC126&&fileType=pdf; ComponentLink1URL: http://www.ti.com/general/docs/lit/getliterature.tsp?genericPartNumber=SN54AHC126&&fileType=pdf; ComponentLink2Description: http://www.ti.com/lit/ds/symlink/sn54ahc126.pdf; ComponentLink2URL: http://www.ti.com/lit/ds/symlink/sn54ahc126.pdf; ComponentLink3Description: http://www.ti.com/lit/ds/symlink/sn74ahc126.pdf; ComponentLink3URL: http://www.ti.com/lit/ds/symlink/sn74ahc126.pdf; ComponentLink4Description: http://www.produktinfo.conrad.com/datenblaetter/1000000-1099999/001016179-da-01-en-IC_BUS_BUFER_QD_SN74AHC126D_SOIC_14_TID.pdf; ComponentLink4URL: http://www.produktinfo.conrad.com/datenblaetter/1000000-1099999/001016179-da-01-en-IC_BUS_BUFER_QD_SN74AHC126D_SOIC_14_TID.pdf; Height: 1.58mm; Lead Free: Lead Free; Length: 8.65mm; Manufacturer Part Number: SN74AHC126D; Max Operating Temperature: 85°C; Min Operating Temperature: -40°C; Mount: Surface Mount; Number of Channels: 4; Number of Elements: 4; Number of Input Lines: 4; Number of Inputs: 4; Number of Output Lines: 4; Number of Outputs: 4; Number of Pins: 14; Package Quantity: 50; Packaging: Rail/Tube; Pricing 1: 1 = 0.40000, 10 = 0.34100, 50 = 0.31860, 100 = 0.25480, 500 = 0.20020, 1000 = 0.15470, 2500 = 0.14105, 5000 = 0.13195 (USD); Radiation Hardening: No; REACH SVHC: No SVHC; RoHS: Yes; Stock 1: 2707; Supplier 1: Digi-Key; Supplier Part Number 1: 296-26392-5-ND; Termination: SMD/SMT; Width: 3.91mm; Library Name: IC_roomi.IntLib
U8 = INA226AQDGSRQ1: IC MONITOR PWR/CURR BIDIR 10MSOP; Manufacturer: Texas Instruments; Accuracy: ±0.02%; Current - Output: 10mA; Function: Current Monitor; Sensing Method: High/Low-Side; Voltage - Input: 0 V ~ 36 V; Category: Integrated Circuits (ICs); Component Kind: Standard; ComponentLink1Description: http://www.ti.com/lit/ds/symlink/ina226-q1.pdf; ComponentLink1URL: http://www.ti.com/lit/ds/symlink/ina226-q1.pdf; Manufacturer Part Number: INA226AQDGSRQ1; Mounting Type: Surface Mount; Operating Temperature: -40°C ~ 125°C; Package / Case: 10-TFSOP, 10-MSOP (0.118", 3.00mm Width); Packaging: Cut Tape (CT); Part Status: Active; Pricing 1: 1=4.06, 10=3.645, 100=2.9866, 500=2.54248, 1000=2.14425 (USD); RoHS: RoHS Compliant; Stock 1: 1929; Supplier 1: Digi-Key; Supplier Device Package: 10-VSSOP; Supplier Part Number 1: 296-42503-1-ND; Library Name: IC_roomi.IntLib
U9 = BME280: PRESSURE HUMIDITY TEMP GAS SENS; Manufacturer: Bosch Sensortec; Accuracy: ±0.145 PSI (±1 kPa); Maximum Pressure: ±290.08 PSI (±2000 kPa); Operating Pressure: 4.35 PSI ~ 15.95 PSI (30 kPa ~ 110 kPa); Output: 16b; Output Type: I²C, SPI; Pressure Type: Vented Gauge; Voltage - Supply: 1.71 V ~ 3.6 V; Category: Sensors, Transducers; Component Kind: Standard; ComponentLink1Description: https://ae-bst.resource.bosch.com/media/_tech/media/datasheets/BST-BME280_DS001-11.pdf; ComponentLink1URL: https://ae-bst.resource.bosch.com/media/_tech/media/datasheets/BST-BME280_DS001-11.pdf; Features: Standby Mode; Manufacturer Part Number: BME280; Operating Temperature: -40°C ~ 85°C; Package / Case: 8-SMD; Port Size: -; Port Style: -; Pricing 1: 1=11, 5=9.652, 10=8.687, 25=7.722, 50=7.1428, 100=6.1776, 500=5.7915, 1000=5.4054, 5000=5.13513 (USD); RoHS: RoHS Compliant; Stock 1: 0; Supplier 1: Digi-Key; Supplier Device Package: 8-SMD; Supplier Part Number 1: 828-1063-1-ND; Termination Style: SMD (SMT) Tab; Library Name: IC_roomi.IntLib
